# Altium schematic sheet pan_tilt.SchDoc
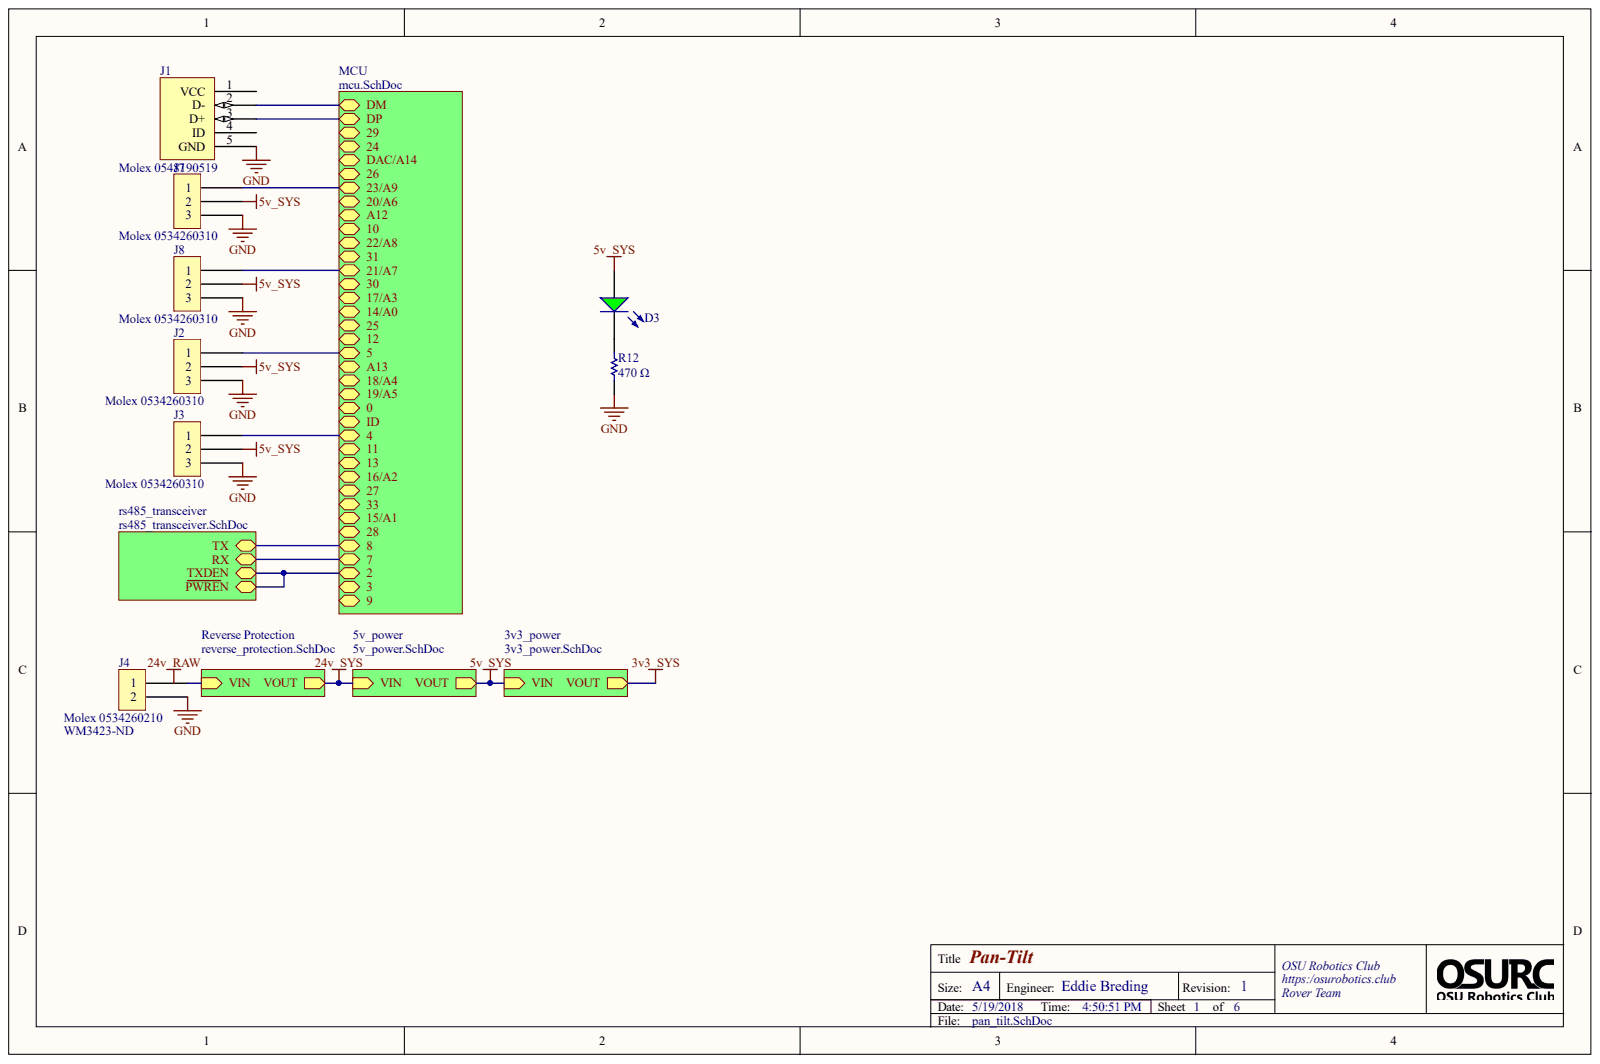
[diagram p0: pan_tilt.SchDoc]

## Parts
D3  SYM-3aeff3ed7c74e528-12  [PCB-divvmvv5iwnkp0y4rvhb-1]  (value not drawn)
J1  Molex 0548190519  Manufacturer Part Number: 0548190519  [PCB-2328442-1]
J2  Molex 0534260310  [PCB-uh5qjayc3e5325ry13yq-1]
J3  Molex 0534260310  [PCB-uh5qjayc3e5325ry13yq-1]
J4  Molex 0534260210  [PCB-rof5jlh7hha4c3onjqk8-1]
J7  Molex 0534260310  [PCB-uh5qjayc3e5325ry13yq-1]
J8  Molex 0534260310  [PCB-uh5qjayc3e5325ry13yq-1]
R12  Resistance: 470 Ω  [PCB-9ahxsq8gddjqcnfjmojj-1]  (hidden comment: Res1)

## Nets
5V_SYS: D3.2 J2.2 J3.2 J7.2 J8.2
GND: J1.5(GND) J2.3 J3.3 J4.2 J7.3 J8.3 R12.1
NetD3_1: D3.1 R12.2
NetJ1_1: J1.1(VCC)
NetJ1_2: J1.2(D-)
NetJ1_3: J1.3(D+)
NetJ1_4: J1.4(ID)
NetJ2_1: J2.1
NetJ3_1: J3.1
NetJ4_1: J4.1
NetJ7_1: J7.1
NetJ8_1: J8.1
0:
2:
3:
3V3_SYS:
7:
8:
9:
10:
11:
12:
13:
14/A0:
15/A1:
16/A2:
17/A3:
18/A4:
19/A5:
20/A6:
22/A8:
24:
24V_RAW:
24V_SYS:
25:
26:
27:
28:
29:
30:
31:
33:
A12:
A13:
DAC/A14:
ID:

## Library data of the parts
D3 = CMP-1544858-16: LED; SMD; 0805; green; 1.8-6mcd; 2x1.25x0.8mm; 130°; 2.1÷2.4V; 10mA; Manufacturer Part Number: LTST-C171GKT; Forward Voltage: 2.10 V; Wavelength: 585 nm; Component Kind: Standard; Library Name: CommunityVault; Octopart URL: http://octopart.com/ltst-c171gkt-lite-on-549885; Size-Height: 800 µm; Size-Length: 2.00 mm; Viewing Angle: 130 °
J1 = CMP-2328442-3: Conn Mini USB Type B F 5 POS 1.6mm Solder RA Thru-Hole 5 Terminal 1 Port Tray; Body Orientation: Right Angle; Gender: RCP; Number of Contacts: 5; CiivaID: 2328442; Ciiva URL: https://ciiva.com/part/0548190519-2328442; Component Kind: Standard; Library Name: CommunityVault; Mounting: Through Hole; Packaging: Trays; Pitch: 1.6 mm; Termination Method: Solder; Type: USB
J2 J3 J7 J8 = CMP-86e74f44d2545920-1: Conn Shrouded Header HDR 3 POS 2.5mm Solder RA Thru-Hole Mini-Lock™ Tray; Component Kind: Standard; Library Name: CommunityVault; Lifecycle Status: Not Listed by Manufacturer; Mounting Style: Solder; OctopartID: 86e74f44d2545920; Octopart URL: http://octopart.com/0534260310-molex-11104725; RoHS: Compliant
J4 = CMP-c5fa0df034211a3d-1: Conn Shrouded Header HDR 2 POS 2.5mm Solder RA Thru-Hole Mini-Lock™ Tray; Component Kind: Standard; Library Name: CommunityVault; Lifecycle Status: Not Listed by Manufacturer; OctopartID: c5fa0df034211a3d; Octopart URL: http://octopart.com/0534260210-molex-16831677; RoHS: Compliant
R12 = CMP-81ae49818f775e69-1: RMCF Series 0603 470 Ohm ±1 % 0.1 W ±100 ppm/°C SMT Thick Film Chip Resistor; Manufacturer Part Number: RMCF0603FT470R; Lead-Free Status: Lead Free; Power Rating: 100 mW; Resistance Tolerance: ±1%; Component Kind: Standard; Library Name: CommunityVault; Mounting Style: Surface Mount; OctopartID: 81ae49818f775e69; RoHS: Compliant
